# Altium schematic sheet IMU.SchDoc(IMU)
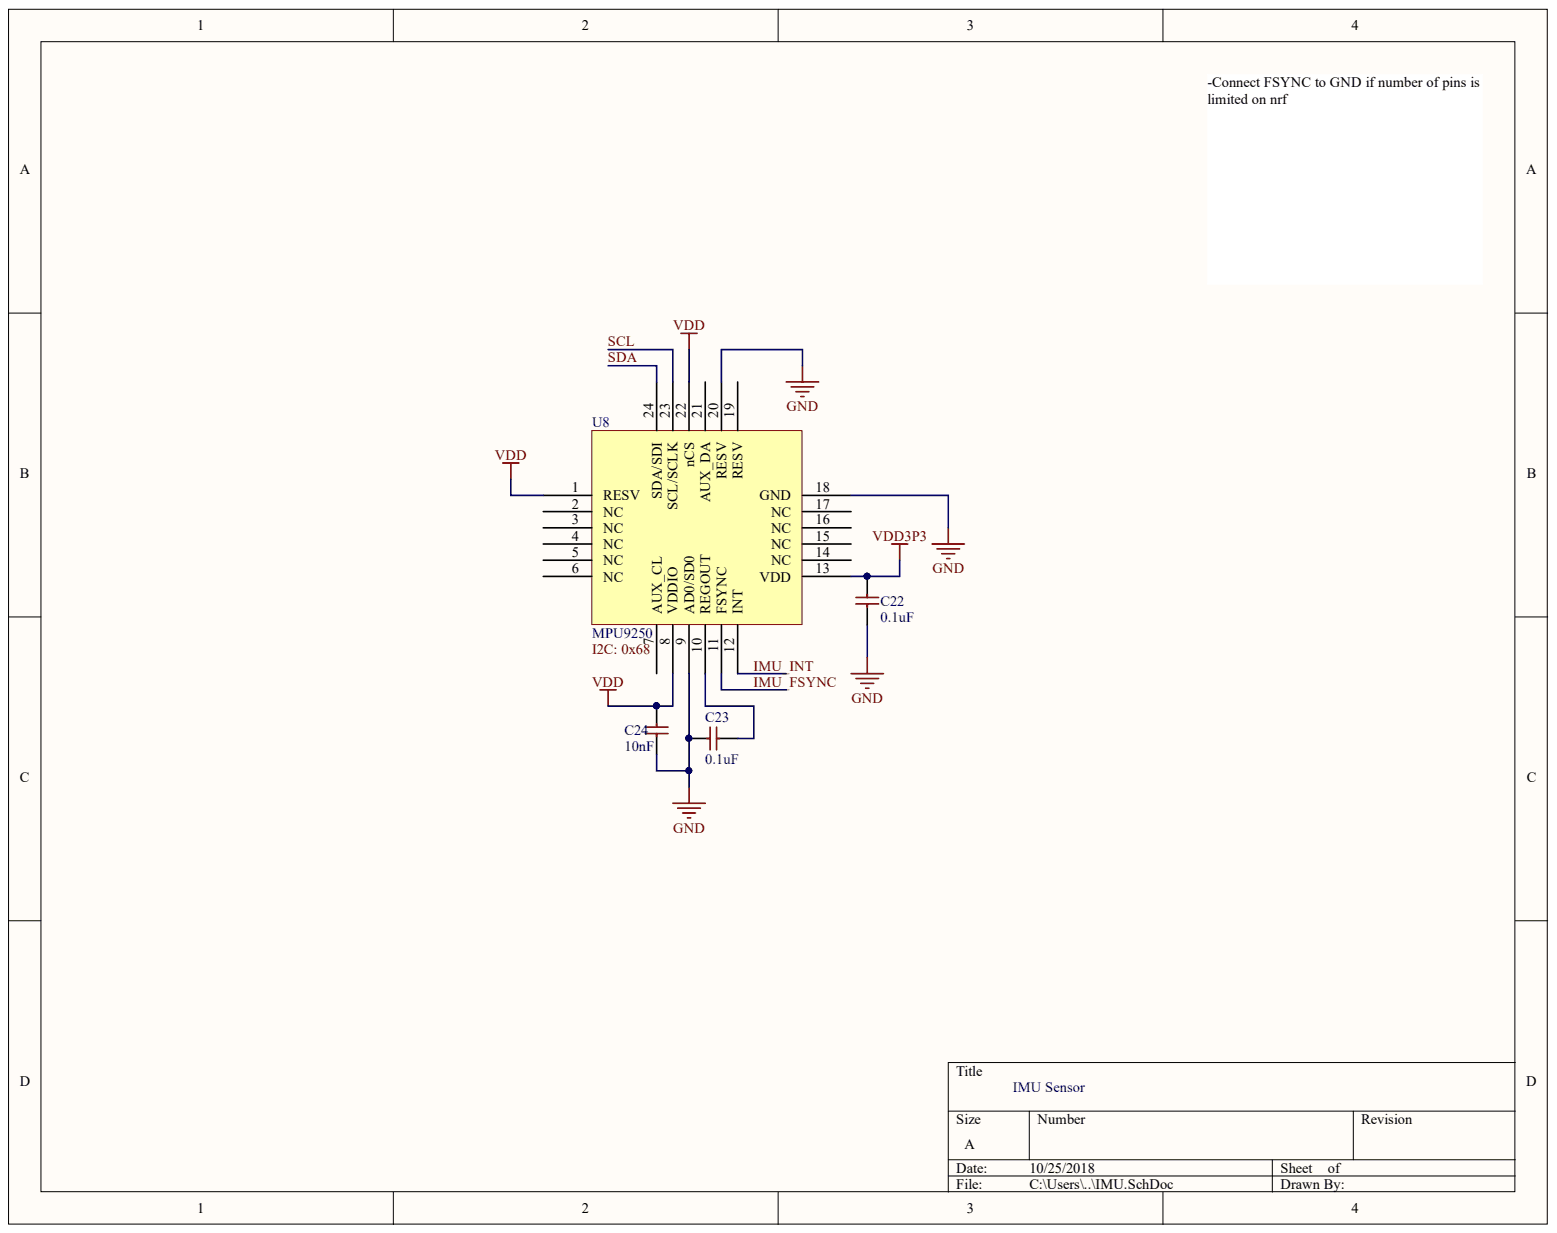
[diagram p0: IMU.SchDoc]

## Parts
C22  0.1uF  [CAP0603]
C23  0.1uF  [CAP0603]
C24  10nF  [CAP0603]
U8  MPU9250  [QFN24-MPU9250]

## Nets
GND: C22.2 C23.2 C24.1 U8.9(AD0/SD0) U8.18(GND) U8.20(RESV)
VDD: C24.2 U8.1(RESV) U8.8(VDDIO) U8.22(nCS)
VDD3P3: C22.1 U8.13(VDD)
IMU_FSYNC: U8.11(FSYNC)
IMU_INT: U8.12(INT)
SCL: U8.23(SCL/SCLK)
SDA: U8.24(SDA/SDI)
NetC23_1: C23.1 U8.10(REGOUT)

Not connected: U8 2(NC) 3(NC) 4(NC) 5(NC) 6(NC) 7(AUX_CL) 14(NC) 15(NC) 16(NC) 17(NC) 19(RESV) 21(AUX_DA)

## Library data of the parts
C22-C24 = Capacitor: Component Kind: Standard; Library Name: karo_components.IntLib
U8 = MPU9250: Component Kind: Standard; Library Name: karo_components.IntLib
